# Altium schematic sheet 014_SoCEhternetControllers.SchDoc(014_SoCEhternetControllers)
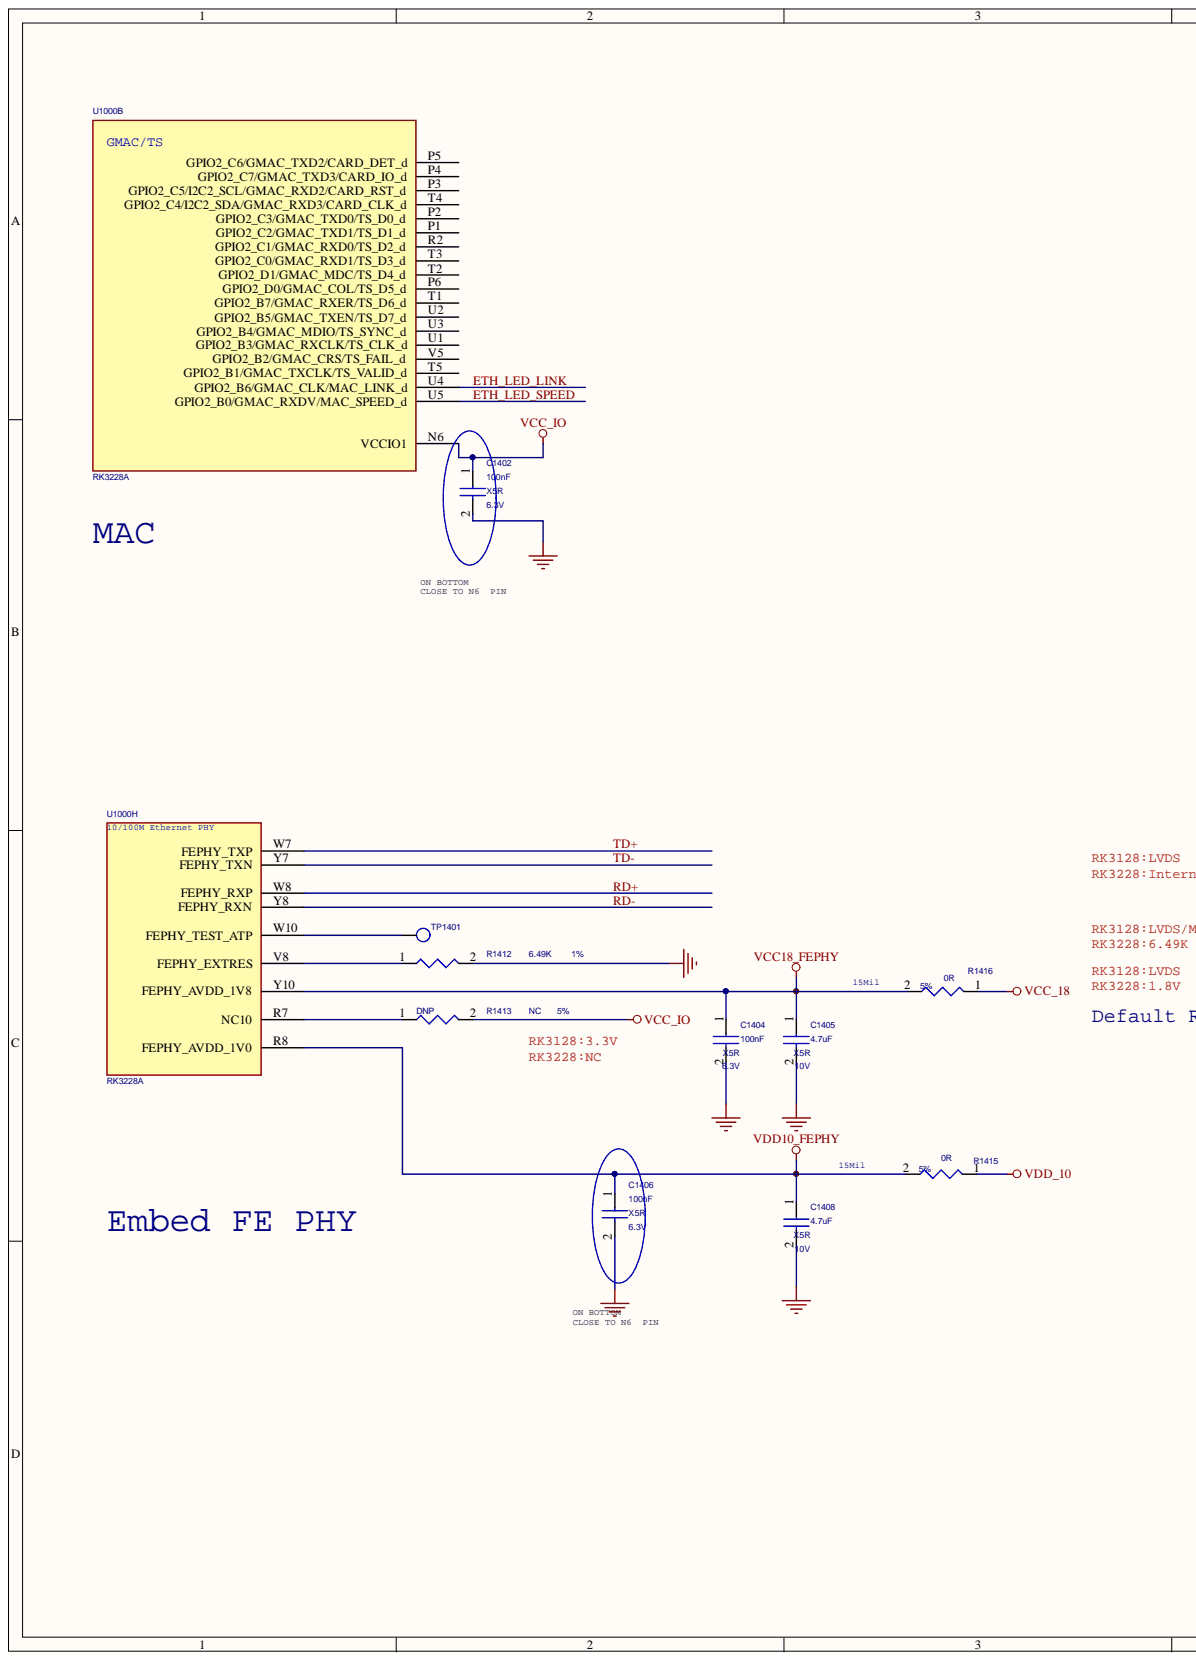
[diagram p0: 014_SoCEhternetControllers.SchDoc, left]
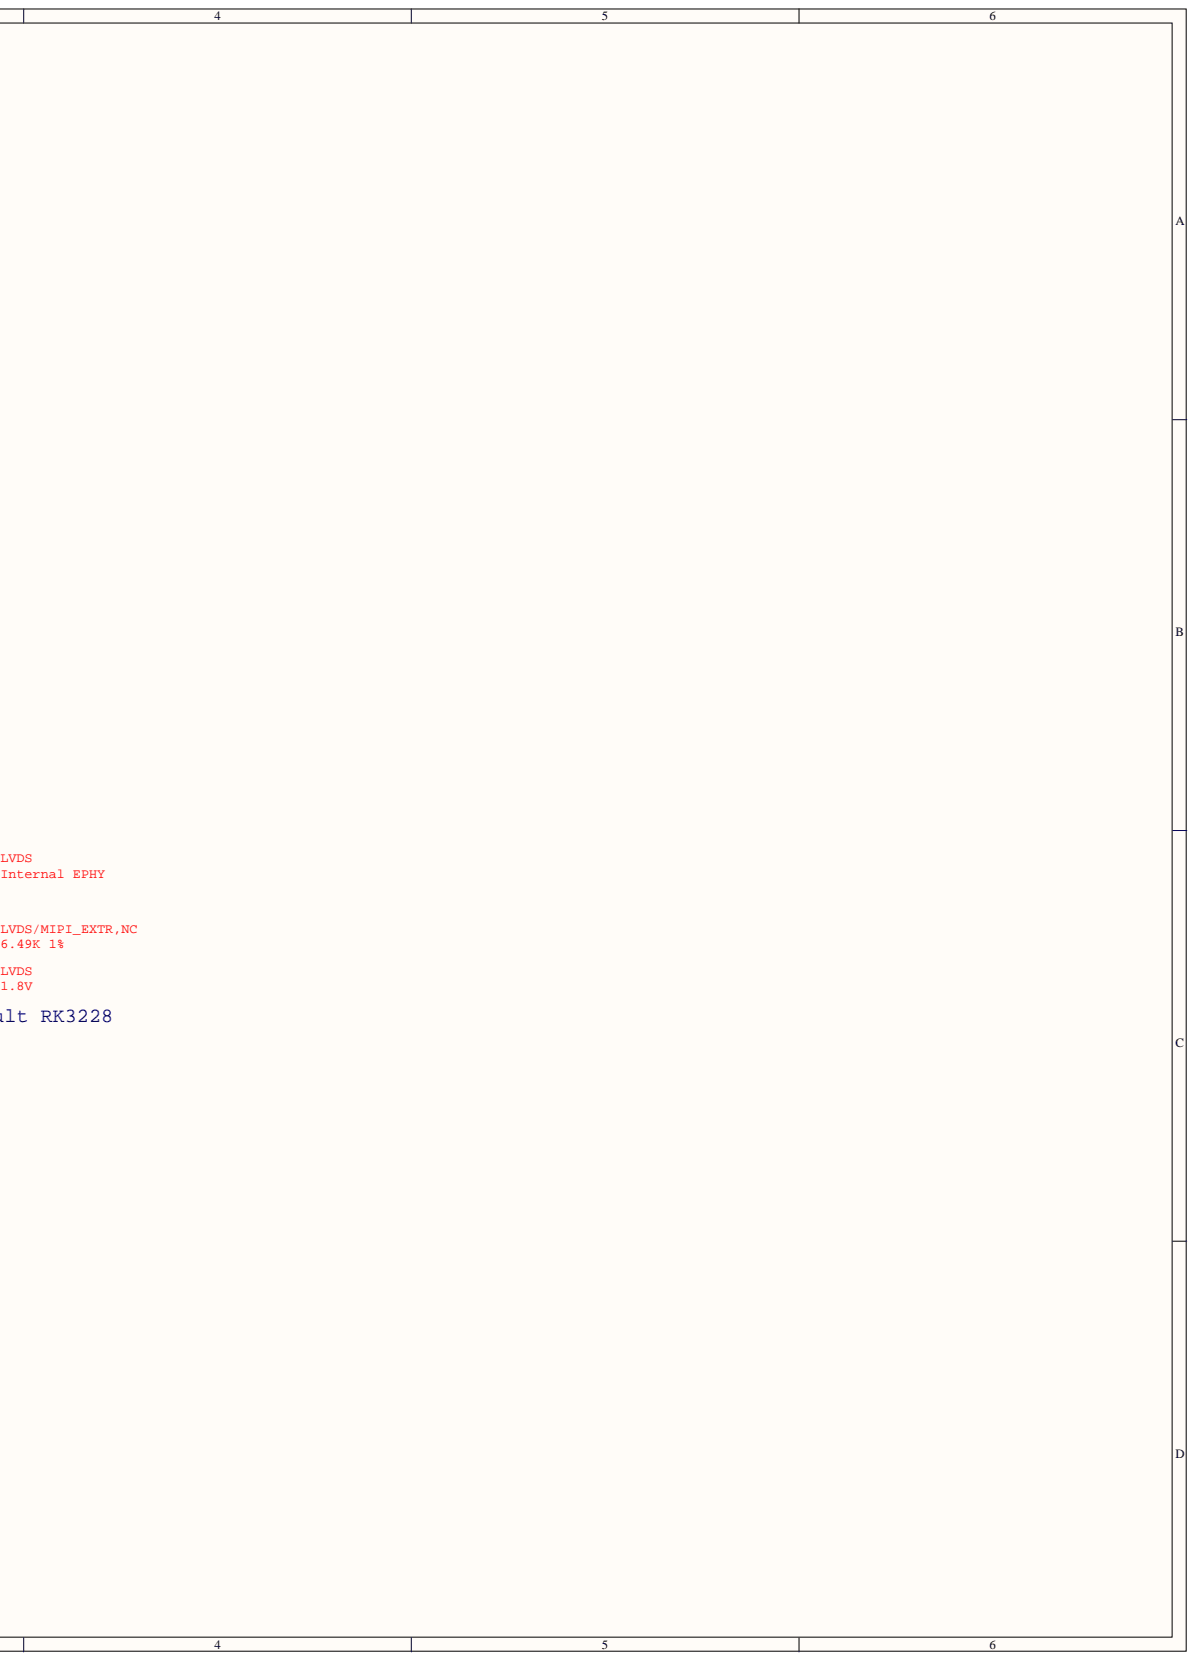
[diagram p1: 014_SoCEhternetControllers.SchDoc, right]

## Parts
C1402  100nF  Voltage: 6.3V  Dielectric: X5R  [C0402]
C1404  100nF  Voltage: 6.3V  Dielectric: X5R  [C0402]
C1405  4.7uF  Voltage: 10V  Dielectric: X5R  [C0603]
C1406  100nF  Voltage: 6.3V  Dielectric: X5R  [C0402]
C1408  4.7uF  Voltage: 10V  Dielectric: X5R  [C0603]
R1412  6.49K  [R0402]
R1413  NC  option: DNP  [R0402]
R1415  0R  Tolerance: 5%  [R0402]
R1416  0R  Tolerance: 5%  [R0402]
TP1401  TP_0.7  [TEST]  (value not drawn)
U1000  RK3228A  [BGA316-14X14_0.65]  (parts B,H)

## Nets
GND: C1402.2 C1404.2 C1405.2 C1406.2 C1408.2 R1412.2
VCC18_FEPHY: C1404.1 C1405.1 R1416.2 U1000.Y10(FEPHY_AVDD_1V8)
VCC_18: R1416.1
VCC_IO: C1402.1 R1413.2 U1000.N6(VCCIO1)
VDD10_FEPHY: C1406.1 C1408.1 R1415.2 U1000.R8(FEPHY_AVDD_1V0)
VDD_10: R1415.1
ETH_LED_LINK: U1000.U4(GPIO2_B6/GMAC_CLK/MAC_LINK_d)
ETH_LED_SPEED: U1000.U5(GPIO2_B0/GMAC_RXDV/MAC_SPEED_d)
RD+: U1000.W8(FEPHY_RXP)
RD-: U1000.Y8(FEPHY_RXN)
TD+: U1000.W7(FEPHY_TXP)
TD-: U1000.Y7(FEPHY_TXN)
NetR1412_1: R1412.1 U1000.V8(FEPHY_EXTRES)
NetR1413_1: R1413.1 U1000.R7(NC10)
NetTP1401_1: TP1401.1 U1000.W10(FEPHY_TEST_ATP)

Not connected: U1000 P1(GPIO2_C2/GMAC_TXD1/TS_D1_d) P2(GPIO2_C3/GMAC_TXD0/TS_D0_d) P3(GPIO2_C5/I2C2_SCL/GMAC_RXD2/CARD_RST_d) P4(GPIO2_C7/GMAC_TXD3/CARD_IO_d) P5(GPIO2_C6/GMAC_TXD2/CARD_DET_d) P6(GPIO2_D0/GMAC_COL/TS_D5_d) R2(GPIO2_C1/GMAC_RXD0/TS_D2_d) T1(GPIO2_B7/GMAC_RXER/TS_D6_d) T2(GPIO2_D1/GMAC_MDC/TS_D4_d) T3(GPIO2_C0/GMAC_RXD1/TS_D3_d) T4(GPIO2_C4/I2C2_SDA/GMAC_RXD3/CARD_CLK_d) T5(GPIO2_B1/GMAC_TXCLK/TS_VALID_d) U1(GPIO2_B3/GMAC_RXCLK/TS_CLK_d) U2(GPIO2_B5/GMAC_TXEN/TS_D7_d) U3(GPIO2_B4/GMAC_MDIO/TS_SYNC_d) V5(GPIO2_B2/GMAC_CRS/TS_FAIL_d)

## Library data of the parts
C1402 C1404 C1406 = CAP NP_Dup5: cap,100.00nF,+/-10%,6.3V,X5R,C0402; Manufacturer: TDK; Tolerance: 10%; RK PN: C1005X5R0J104K050BA; Priority: A; Number: 37; Part Type: C0402; Manufacturer PN: C1005X5R0J104K050BA; Component Kind: Standard; C_Voltage: 6.3V; Created by: JJJ
C1405 C1408 = CAP NP_Dup5: cap,4.70uF,+/-10%,10V,X5R,C0603; Manufacturer: TDK; Tolerance: 10%; RK PN: C1608X5R1A475K080AC; Priority: A; C_Voltage: 6.3V; Part Type: C0603; Manufacturer PN: C1608X5R1A475K080AC; Component Kind: Standard; Created by: JJJ
R1412 = RESISTOR_Dup1: 哥棨슌훡롯量,6K49,+/-1%,R0402,1/16W.; Manufacturer: YAGEO; Wattage: 1/16W; Tolerance: 1%; RK PN: RC0402FR-076K49L; Priority: A; Part Type: 哥棨슌훡롯量; Manufacturer PN: RC0402FR-076K49L; Component Kind: Standard; Created by: YTL
R1413 = RESISTOR_Dup1: 哥棨슌훡롯量,0R,+/-5%,R0402,1/16W.; Manufacturer: YAGEO; Wattage: 1/16W; Tolerance: 5%; RK PN: RC0402JR-070RL; Priority: A; Part Type: 哥棨슌훡롯量; Manufacturer PN: RC0402JR-070RL; Component Kind: Standard; Created by: YTL
R1415 R1416 = RESISTOR_Dup1: 哥棨슌훡롯量,0R,+/-5%,R0402,1/16W.; Manufacturer: YAGEO; Wattage: 1/16W; RK PN: RC0402JR-070RL; Priority: A; Part Type: 哥棨슌훡롯量; Manufacturer PN: RC0402JR-070RL; Component Kind: Standard; Created by: YTL
TP1401 = TP: Test Point 0.7mm; RK PN: TESTPONIT_0R7; Priority: A; Part Type: TP; Created Date: 20140617; Component Kind: Standard; Created by: CZD
U1000 = DSP_RK3228: ROCKCHIP CPU; Manufacturer: Rockchip (쐉拱匣); RK PN: RK3228; Priority: A; Part Type: DSP; Manufacturer PN: RK3228; Component Kind: Standard; Created by: JJJ
